# Altium schematic sheet Motor_Controller.SchDoc
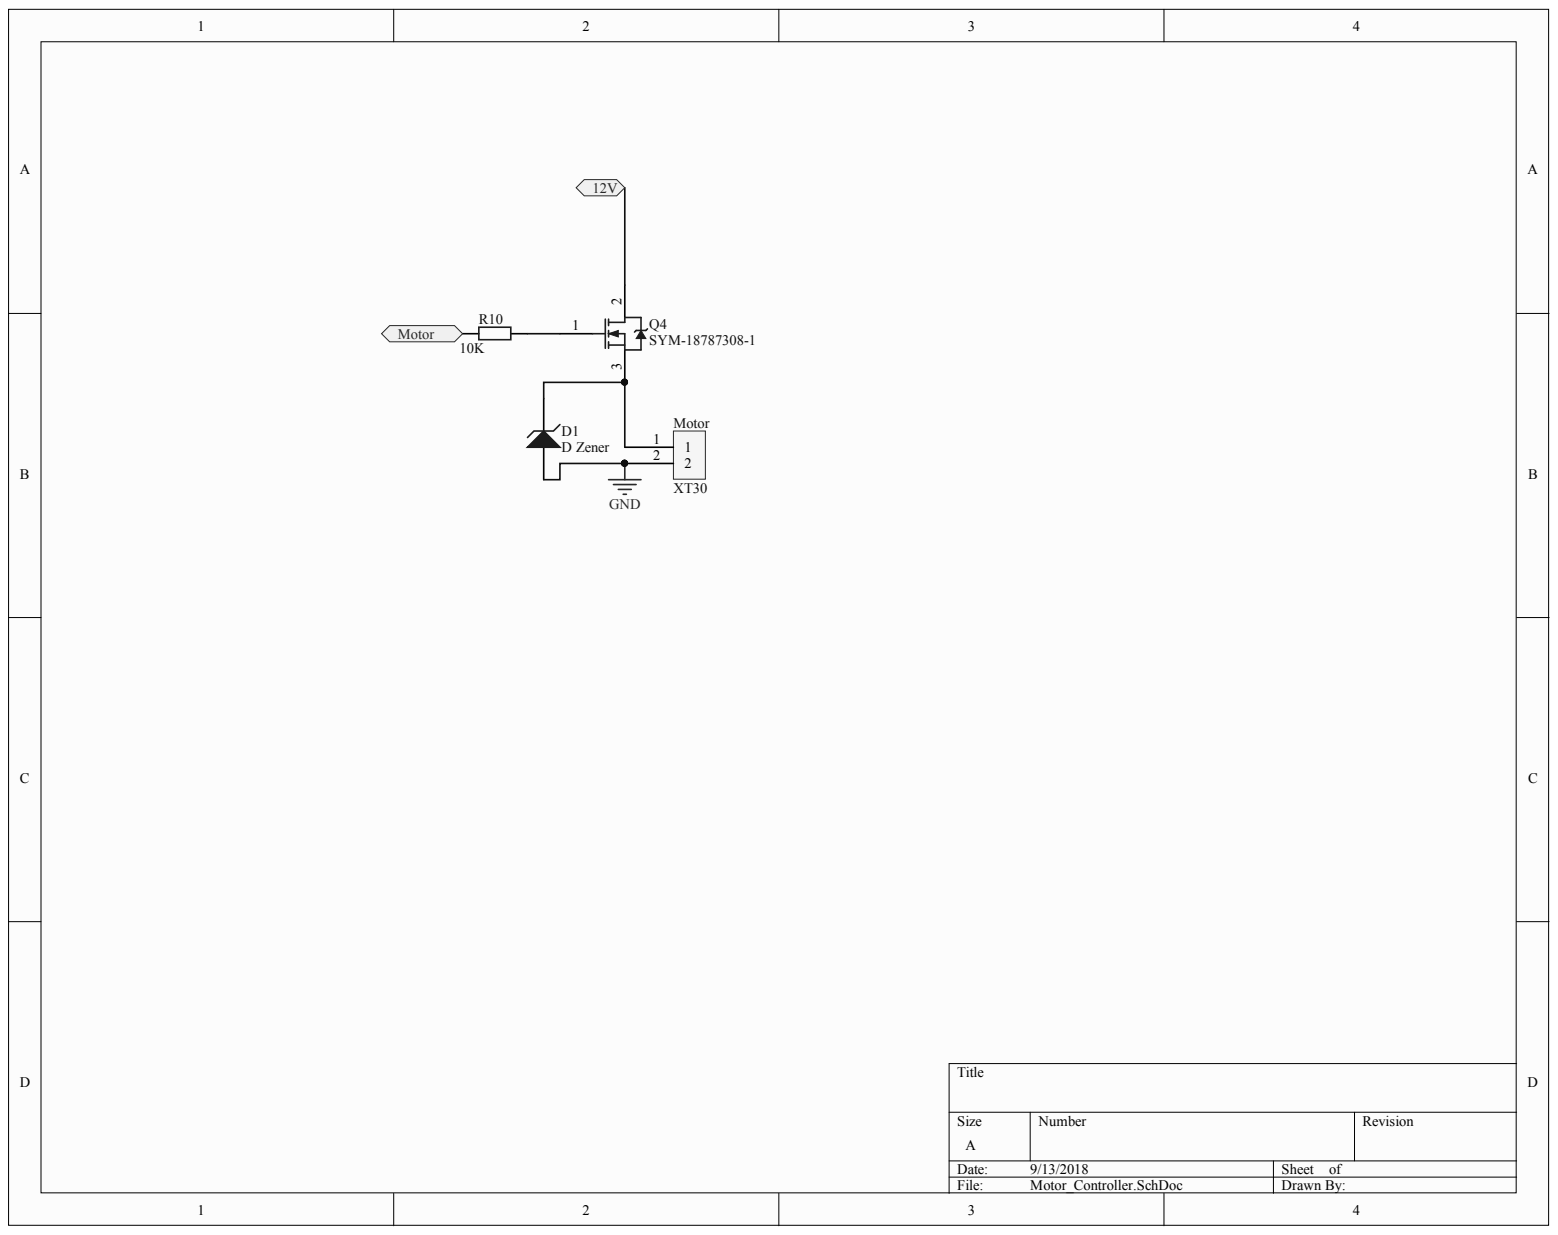
[diagram p0: Motor_Controller.SchDoc]

## Parts
D1  D Zener  [PCB-l9es7xv56lx35n1ll0aq-1]
Motor  XT30  [PCB-8h6goupi0sbkduz6cbnu-1]
Q4  SYM-18787308-1  [PCB-18787308-1]
R10  Ohms: 10K  [PCB-mctr3h3tvednl0z90ud0-1]  (hidden comment: Res2)

## Ports
12V, MOTOR

## Nets
GND: D1.1 Motor.2
MOTOR: R10.1 ; port MOTOR
12V: Q4.2 ; port 12V
NetD1_2: D1.2 Motor.1 Q4.3
NetQ4_1: Q4.1 R10.2

## Library data of the parts
D1 = CMP-88cd428957ea570a-1: 1N4745A Series 1.3 W 16 V 200 mA 5 % Tolerance Through Hole Zener Diode - DO-41; Manufacturer Part Number: 1N4745A-TR; Power Rating: 1.00 W; Case/Package: DO-41; Component Kind: Standard; Library Name: CommunityVault; Lifecycle Status: Active; Number of Pins: 2; OctopartID: 88cd428957ea570a; Octopart URL: http://octopart.com/1n4745a-tr-vishay-55507521; RoHS: Compliant
Motor = CMP-nlx8yci0umf6n9sk0ty3-1: Very similar to the XT60 models, but smaller and made for 30A; Component Kind: Standard; CustomComponentID: nlx8yci0umf6n9sk0ty3; Homepage URL: https://www.amazon.com/ProgressiveRC-AC-XT30-XT30-Connectors-pairs/dp/B011WDZGM0; Library Name: CommunityVault
Q4 = CMP-18787308-5: MS; Part Family: IRFZ44N; Breakdown Voltage (Drain to Source): 55.0 V; Continuous Drain Current (Ids): 49.0 A; Drain to Source Resistance (on) (Rds): 17.5 mΩ; Gate Charge: 63.0 nC; Input Capacitance: 1.47 nF; Lead-Free Status: Lead Free; Power Dissipation: 83.0 W; Rise Time: 60.0 ns; Component Kind: Standard; Current Rating: 49.0 A; Library Name: CommunityVault; Lifecycle Status: Active; Mounting Style: Through Hole; Number of Pins: 3; OctopartID: 444b8d311b939b8c; Octopart URL: http://octopart.com/irfz44npbf-international+rectifier-7371454; REACH SVHC Compliance: No SVHC; Voltage Rating (DC): 55.0 V
R10 = CMP-1413dd18cda2cbd4-1: Resistor; Carbon Composition; Res 3.9 Kilohms; Pwr-Rtg 0.25 W; Tol 5%; Axial; Manufacturer Part Number: OD392JE; Lead-Free Status: Lead Free; Power Rating: 250 mW; Component Kind: Standard; Library Name: CommunityVault; Mounting Style: Through Hole, Axial; OctopartID: 1413dd18cda2cbd4; Resistance Tolerance: ±5%; Size-Diameter: 2.50 mm
